# Altium schematic sheet supply_rfm_connectors.SchDoc(supply_rfm_connectors)
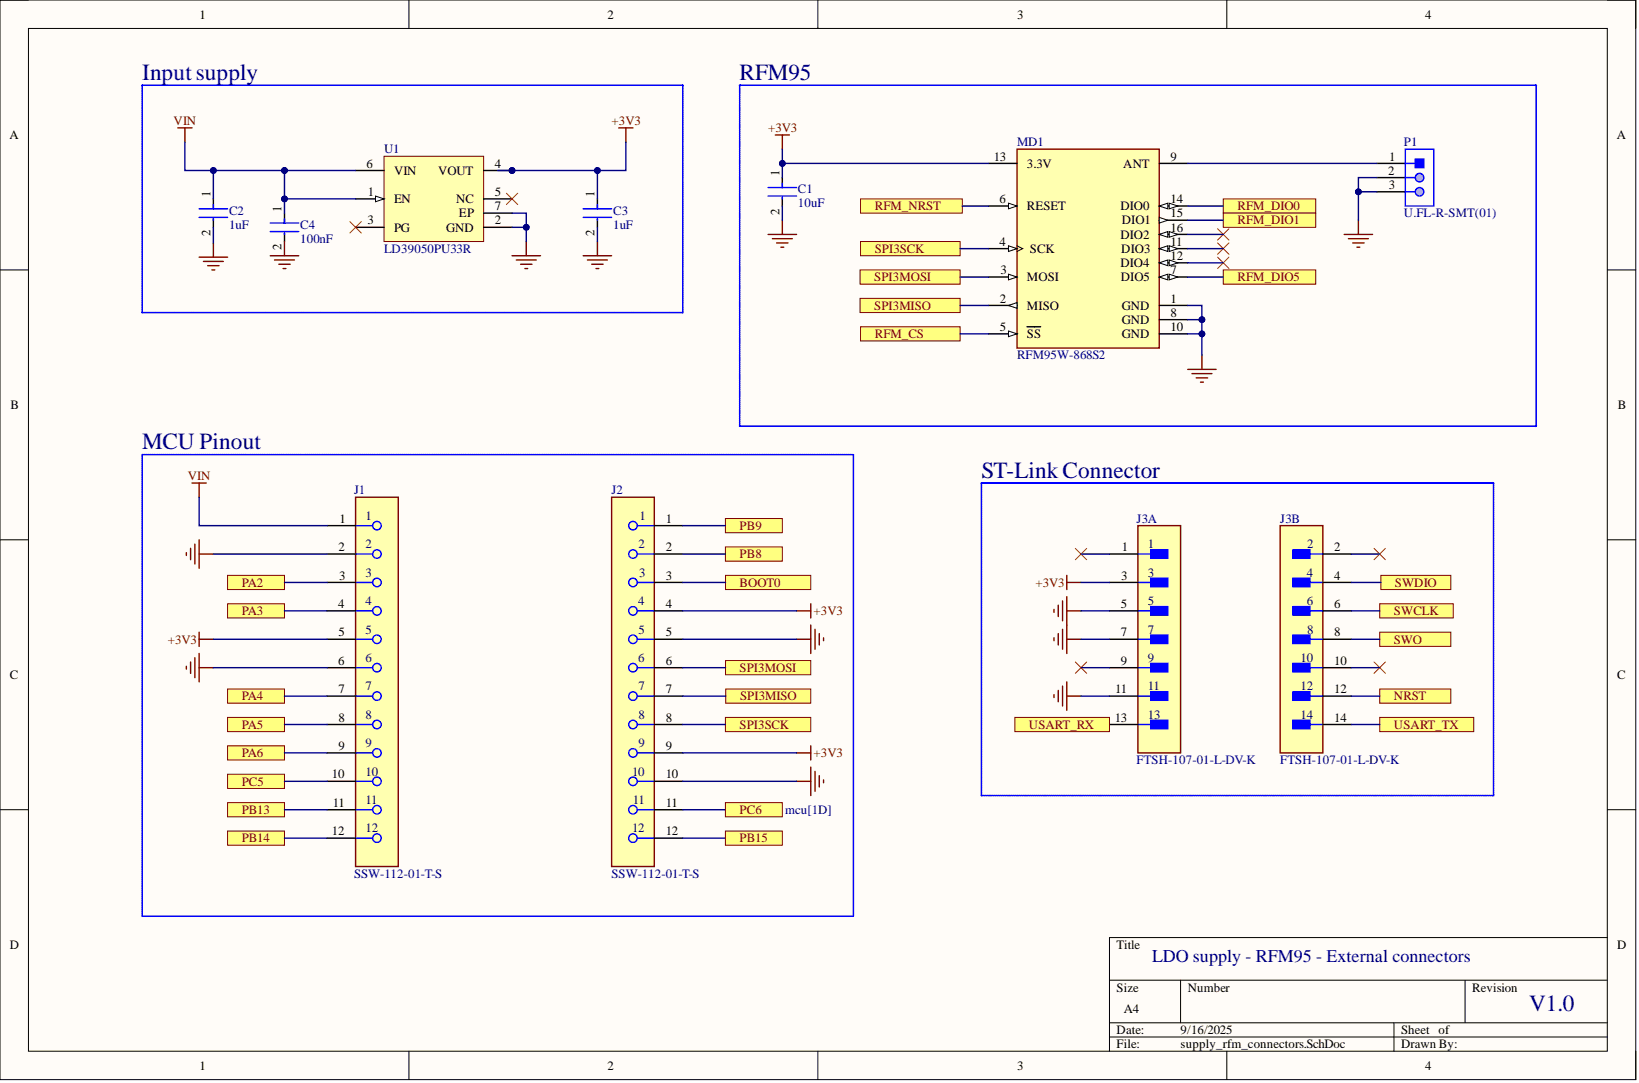
[diagram p0: supply_rfm_connectors.SchDoc]

## Parts
C1  10uF
C2  1uF
C3  1uF
C4  100nF
J1  SSW-112-01-T-S
J2  SSW-112-01-T-S
J3  FTSH-107-01-L-DV-K  (parts A,B)
MD1  RFM95W-868S2
P1  U.FL-R-SMT(01)
U1  LD39050PU33R

## Ports
BOOT0, NRST, PA2, PA3, PA4, PA5, PA6, PB8, PB9, PB13, PB14, PB15, PC5, PC6, RFM_CS, RFM_DIO0, RFM_DIO1, RFM_DIO5, RFM_NRST, SPI3MISO, SPI3MOSI, SPI3SCK, SWCLK, SWDIO, SWO, USART_RX, USART_TX

## Nets
+3V3: C1.1 C3.1 J1.5 J2.4 J2.9 J3.3 MD1.13(3.3V) U1.4(VOUT)
GND: C1.2 C2.2 C3.2 C4.2 J1.2 J1.6 J2.5 J2.10 J3.5 J3.7 J3.11 MD1.1(GND) MD1.8(GND) MD1.10(GND) P1.2 P1.3 U1.2(GND) U1.7(EP)
VIN: C2.1 C4.1 J1.1 U1.1(EN) U1.6(VIN)
BOOT0: J2.3 ; port BOOT0
NRST: J3.12 ; port NRST
PA2: J1.3 ; port PA2
PA3: J1.4 ; port PA3
PA4: J1.7 ; port PA4
PA5: J1.8 ; port PA5
PA6: J1.9 ; port PA6
PB8: J2.2 ; port PB8
PB9: J2.1 ; port PB9
PB13: J1.11 ; port PB13
PB14: J1.12 ; port PB14
PB15: J2.12 ; port PB15
PC5: J1.10 ; port PC5
PC6: J2.11 ; port PC6
RFM_CS: MD1.5(SS) ; port RFM_CS
RFM_DIO0: MD1.14(DIO0) ; port RFM_DIO0
RFM_DIO1: MD1.15(DIO1) ; port RFM_DIO1
RFM_DIO5: MD1.7(DIO5) ; port RFM_DIO5
RFM_NRST: MD1.6(RESET) ; port RFM_NRST
SPI3MISO: J2.7 MD1.2(MISO) ; port SPI3MISO
SPI3MOSI: J2.6 MD1.3(MOSI) ; port SPI3MOSI
SPI3SCK: J2.8 MD1.4(SCK) ; port SPI3SCK
SWCLK: J3.6 ; port SWCLK
SWDIO: J3.4 ; port SWDIO
SWO: J3.8 ; port SWO
USART_RX: J3.13 ; port USART_RX
USART_TX: J3.14 ; port USART_TX
NetMD1_9: MD1.9(ANT) P1.1

Not connected: J3 1 2 9 10; MD1 11(DIO3) 12(DIO4) 16(DIO2); U1 3(PG) 5(NC)

## Library data of the parts
C1 = CMP-13271-000175-1: CL21 Series 0805 10uF 6.3V ±10% Tolerance X6S Multilayer Ceramic Chip Capacitor; Capacitance: 10uF; Case Code (Imperial): 0805; Case Code (Metric): 2012; Case/Package: 0805; Component Kind: Standard; Depth: 1.25mm; Dielectric: X6S; Height: 1.25mm; Lead Free: Lead Free; Length: 2mm; Library Name: Altium Content Vault; Max Operating Temperature: 105°C; Min Operating Temperature: -55°C; Mount: Surface Mount; Package Quantity: 1; Packaging: Tape and Reel; Radiation Hardening: No; Thickness: 0.055inch; Tolerance: 10%; Voltage Rating: 6.3V; Voltage Rating (DC): 6.3V; Width: 0.049inch
C2 C3 = CMP-13271-002018-1: CL21 Series 0805 1uF 25V -20/+80% Tolerance Y5V Multilayer Ceramic Chip Capacitor; Tolerance: 80%; Capacitance: 1uF; Case/Package: 0805; Component Kind: Standard; Depth: 1.25mm; Dielectric: Y5V; Height: 1.25mm; Length: 2mm; Library Name: Altium Content Vault; Max Operating Temperature: 85°C; Min Operating Temperature: -30°C; Mount: Surface Mount; Package Quantity: 10000; Packaging: Tape and Reel; Radiation Hardening: No; Thickness: 0.053inch; Voltage Rating: 25V; Voltage Rating (DC): 25V; Width: 0.049inch
C4 = CMP-13271-003801-1: Cap Ceramic 100nF 6.3V X5R ±10% SMD 0402 +85°C Paper T/R; Capacitance: 100nF; Case Code (Imperial): 0402; Case Code (Metric): 1005; Case/Package: 0402; Component Kind: Standard; Depth: 0.5mm; Dielectric: X5R; Height: 0.5mm; Lead Free: Lead Free; Length: 1mm; Library Name: Altium Content Vault; Max Operating Temperature: 85°C; Min Operating Temperature: -55°C; Mount: Surface Mount; Package Quantity: 1; Packaging: Tape and Reel; Radiation Hardening: No; Thickness: 0.022inch; Tolerance: 10%; Voltage Rating: 6.3V; Voltage Rating (DC): 6.3V; Width: 0.02inch
J1 J2 = CMP-1023-00407-2: CONN RCPT 12POS 0.1 TIN PCB; Connector Type: Receptacle; Contact Resistance: 10mR; Mating Cycles: 100; Max Current Rating: 6.9A; Max Voltage Rating (AC): 550V; Number of Contacts: 12; Pitch: 2.54mm; Color: Black; Component Kind: Standard; Contact Material: Bronze; Contact Plating: Tin; Contact Type: Female Socket; Depth: 2.41mm; Gender: Receptacle; Housing Color: Black; Housing Material: Polymer; Lead Free: Lead Free; Length: 30.99mm; Library Name: Altium Content Vault; Max Operating Temperature: 105°C; Min Operating Temperature: -55°C; Mount: Through Hole; Number of Positions: 12; Number of Rows: 1; Orientation: Vertical; Packaging: Bulk; REACH SVHC: No SVHC; RoHS Compliant: Yes; Series: SSW; Termination: Solder; Width: 2.41mm
J3 = CMP-02766-001412-1: CONN HEADER SMD 14POS 1.27MM; Gender: Male; Number of Contacts: 14; Component Kind: Standard; Contact Material: Bronze; Contact Plating: Gold; Depth: 5.84mm; Housing Color: Black; Housing Material: Polymer; Lead Free: Lead Free; Length: 8.89mm; Library Name: Altium Content Vault; Max Operating Temperature: 125°C; Min Operating Temperature: -55°C; Number of Rows: 2; Orientation: Straight; Packaging: Rail/Tube; Pitch: 1.27mm; Radiation Hardening: No; RoHS Compliant: Yes; Termination: Solder
MD1 = CMP-204316-000001-1: RX TXRX MODULE ISM < 1GHZ SMD; Current - Receiving: 12.1mA; Current - Transmitting: 120mA; Data Rate: 300Kbps; Frequency: 868MHz; Interface: SPI; Sensitivity: -123dBm; Case/Package: SMD/SMT; Component Kind: Standard; Library Name: Altium Content Vault; Max Operating Temperature: 70°C; Min Operating Temperature: -20°C; Mount: Surface Mount; Packaging: Bulk
P1 = CMP-2000-05151-1: CONN RECPT ULTRA-MINI COAX SMD; Manufacturer: Hirose Electric; Approval Category: UL94V-0; Body Plating: Silver; Body Style: StraightJack; Centre Contact Resistance: 25mohm; Coaxial Termination: Solder; Connector Mounting: PCB; Connector Mounting Orientation: PCBoard; Connector Type: UFLCoaxial; Contact Resistance: 10mohm; External Length / Height: 2.5mm; Female Contact Material: PhosphorBronze; Female Contact Plating: Gold; Frequency Max: 6GHz; Impedance: 50ohm; Insulation Resistance: 500Mohm; Jacket Material: LCP; Male Contact Material: Brass; Secondary Insulation Material: PBT; SVHC: NoSVHC(18-Jun-2012); Voltage Rating V AC: 60V; VSWR: 1.3; Component Kind: Standard; Contact Material: PhosphorBronze; Contact Plating: Gold; Datasheet URL: http://www.farnell.com/datasheets/91524.pdf; Datasheet Version: Feb-04; Flammability Rating: UL94V-0; Library Name: Altium Content Vault; Male Contact Plating: Gold; Manufacturer URL: https://www.hirose.com; Material: PhosphorBronze; Mounting Type: PCBoard; Operating Frequency Max: 6GHz; Operating Temperature Max: 90degC; Operating Temperature Min: -40degC; Outer Contact Resistance: 10mohm; Package Description: 3-Pin Surface Mount Device, Body 2.6 x 2.6 mm; Package Reference: U.FL-R-SMT(10); Termination Method: Solder
U1 = CMP-0244-00288-1: 500 mA Low Quiescent Current Low Noise Voltage Regulator, 3.3V, 6-Pin VDFDPN, Tape and Reel; Component Kind: Standard; ComponentLink1Description: Manufacturer URL; ComponentLink1URL: http://www.st.com; ComponentLink2Description: Datasheet; ComponentLink2URL: http://www.st.com/internet/com/TECHNICAL_RESOURCES/TECHNICAL_LITERATURE/DATASHEET/CD00227527.pdf; DatasheetVersion: 1/03/2009; Library Name: Altium Content Vault; PackageDescription: 6-Pin Plastic, Dual LLP, 3 x 3 mm Body, 0.95 mm Pitch, Exposed Pad; PackageReference: DFN6D; PackageVersion: 2008
